# Altium schematic sheet DISPLAY&PINOUT.SchDoc(DISPLAY&PINOUT)
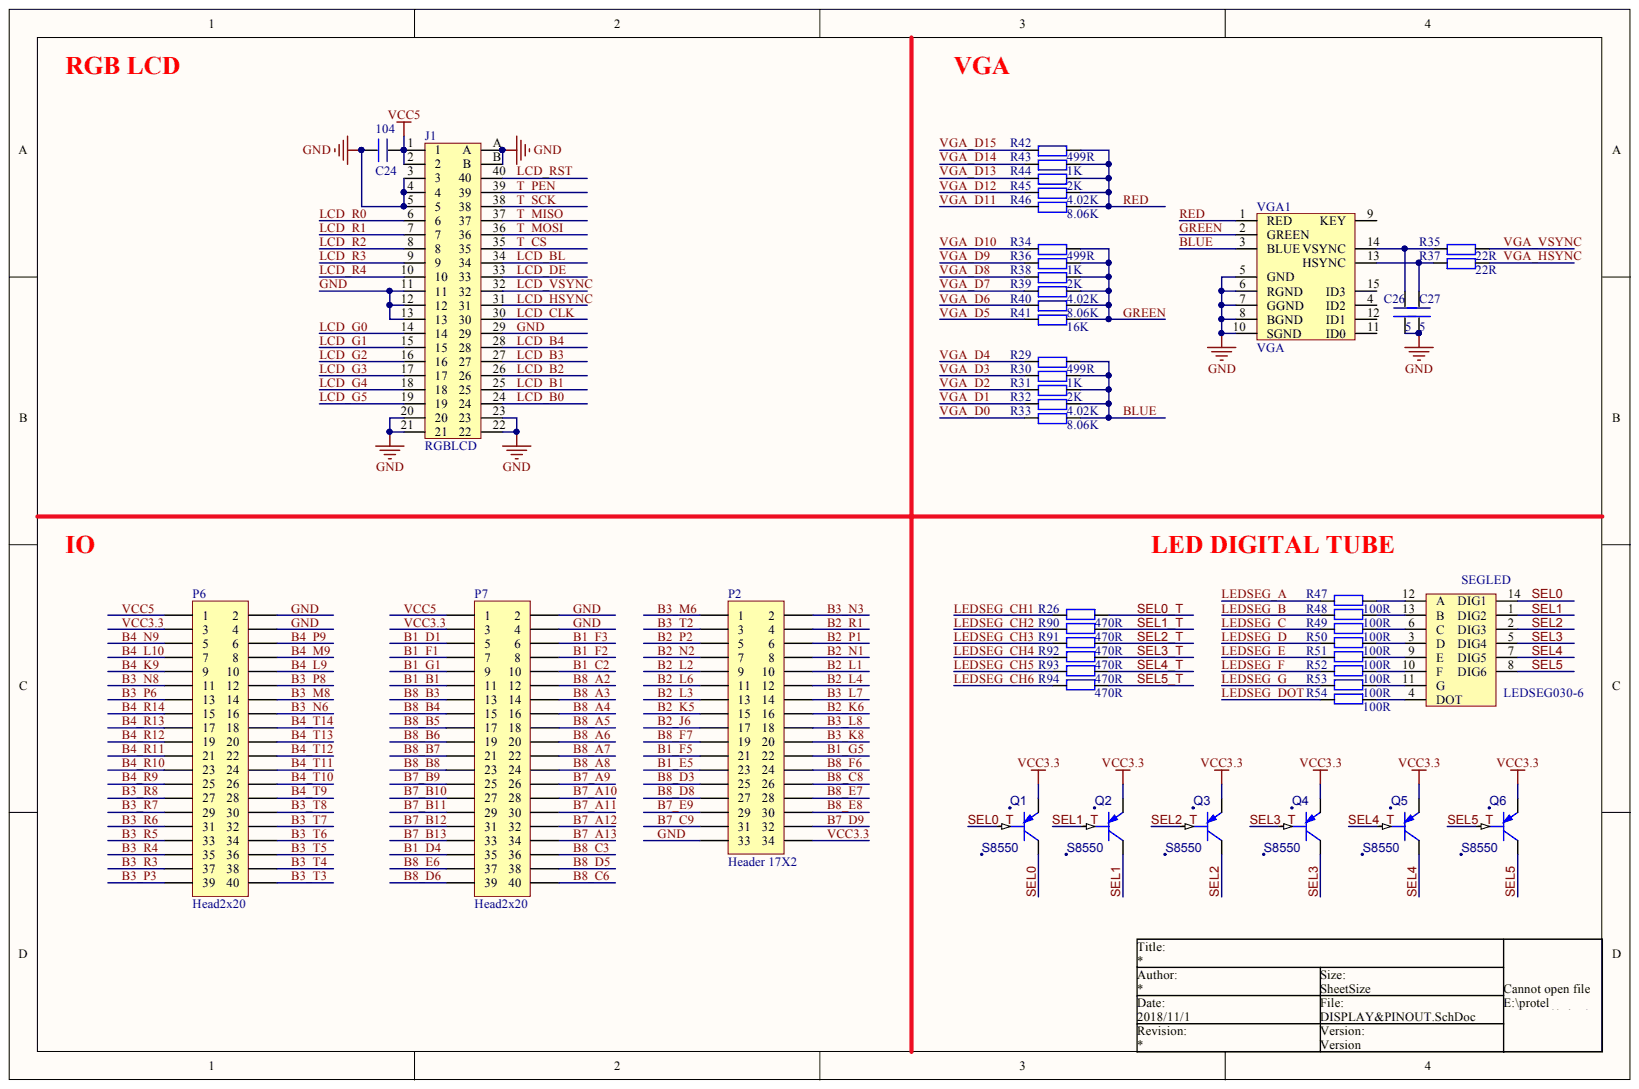
[diagram p0: DISPLAY&PINOUT.SchDoc]

## Parts
C24  104  [0603P]
C26  5  [0603P]
C27  5  [0603P]
J1  RGBLCD  [FPC-TOP40]
P2  Header 17X2  [HDR2X17]
P6  Head2x20  [IDC-40J]
P7  Head2x20  [IDC-40J]
Q1  S8550  [SOT23]
Q2  S8550  [SOT23]
Q3  S8550  [SOT23]
Q4  S8550  [SOT23]
Q5  S8550  [SOT23]
Q6  S8550  [SOT23]
R26  470R  [0603P]
R29  499R  [0603P]
R30  1K  [0603P]
R31  2K  [0603P]
R32  4.02K  [0603P]
R33  8.06K  [0603P]
R34  499R  [0603P]
R35  22R  [0603P]
R36  1K  [0603P]
R37  22R  [0603P]
R38  2K  [0603P]
R39  4.02K  [0603P]
R40  8.06K  [0603P]
R41  16K  [0603P]
R42  499R  [0603P]
R43  1K  [0603P]
R44  2K  [0603P]
R45  4.02K  [0603P]
R46  8.06K  [0603P]
R47  100R  [0603P]
R48  100R  [0603P]
R49  100R  [0603P]
R50  100R  [0603P]
R51  100R  [0603P]
R52  100R  [0603P]
R53  100R  [0603P]
R54  100R  [0603P]
R90  470R  [0603P]
R91  470R  [0603P]
R92  470R  [0603P]
R93  470R  [0603P]
R94  470R  [0603P]
SEGLED  LEDSEG030-6  [leddisplay-0.3]
VGA1  VGA  [DB15]

## Nets
B1_B1: P7.11
B1_C2: P7.10
B1_D1: P7.5
B1_D4: P7.35
B1_E5: P2.23
B1_F1: P7.7
B1_F2: P7.8
B1_F3: P7.6
B1_F5: P2.21
B1_G1: P7.9
B1_G5: P2.22
B2_J6: P2.17
B2_K5: P2.15
B2_K6: P2.16
B2_L1: P2.10
B2_L2: P2.9
B2_L3: P2.13
B2_L4: P2.12
B2_L6: P2.11
B2_N1: P2.8
B2_N2: P2.7
B2_P1: P2.6
B2_P2: P2.5
B2_R1: P2.4
B3_K8: P2.20
B3_L7: P2.14
B3_L8: P2.18
B3_M6: P2.1
B3_M8: P6.14
B3_N3: P2.2
B3_N6: P6.16
B3_N8: P6.11
B3_P3: P6.39
B3_P6: P6.13
B3_P8: P6.12
B3_R3: P6.37
B3_R4: P6.35
B3_R5: P6.33
B3_R6: P6.31
B3_R7: P6.29
B3_R8: P6.27
B3_T2: P2.3
B3_T3: P6.40
B3_T4: P6.38
B3_T5: P6.36
B3_T6: P6.34
B3_T7: P6.32
B3_T8: P6.30
B4_K9: P6.9
B4_L9: P6.10
B4_L10: P6.7
B4_M9: P6.8
B4_N9: P6.5
B4_P9: P6.6
B4_R9: P6.25
B4_R10: P6.23
B4_R11: P6.21
B4_R12: P6.19
B4_R13: P6.17
B4_R14: P6.15
B4_T9: P6.28
B4_T10: P6.26
B4_T11: P6.24
B4_T12: P6.22
B4_T13: P6.20
B4_T14: P6.18
B7_A9: P7.26
B7_A10: P7.28
B7_A11: P7.30
B7_A12: P7.32
B7_A13: P7.34
B7_B9: P7.25
B7_B10: P7.27
B7_B11: P7.29
B7_B12: P7.31
B7_B13: P7.33
B7_C9: P2.31
B7_D9: P2.32
B7_E9: P2.29
B8_A2: P7.12
B8_A3: P7.14
B8_A4: P7.16
B8_A5: P7.18
B8_A6: P7.20
B8_A7: P7.22
B8_A8: P7.24
B8_B3: P7.13
B8_B4: P7.15
B8_B5: P7.17
B8_B6: P7.19
B8_B7: P7.21
B8_B8: P7.23
B8_C3: P7.36
B8_C6: P7.40
B8_C8: P2.26
B8_D3: P2.25
B8_D5: P7.38
B8_D6: P7.39
B8_D8: P2.27
B8_E6: P7.37
B8_E7: P2.28
B8_E8: P2.30
B8_F6: P2.24
B8_F7: P2.19
BLUE: R29.2 R30.2 R31.2 R32.2 R33.2 VGA1.3(BLUE)
GND: C24.1 C26.2 C27.2 J1.3 J1.4 J1.5 J1.11 J1.12 J1.13 J1.20 J1.21 J1.22 J1.23 J1.29 J1.A J1.B P2.33 P6.2 P6.4 P7.2 P7.4 VGA1.5(GND) VGA1.6(RGND) VGA1.7(GGND) VGA1.8(BGND) VGA1.10(SGND)
GREEN: R34.2 R36.2 R38.2 R39.2 R40.2 R41.2 VGA1.2(GREEN)
LCD_B0: J1.24
LCD_B1: J1.25
LCD_B2: J1.26
LCD_B3: J1.27
LCD_B4: J1.28
LCD_BL: J1.34
LCD_CLK: J1.30
LCD_DE: J1.33
LCD_G0: J1.14
LCD_G1: J1.15
LCD_G2: J1.16
LCD_G3: J1.17
LCD_G4: J1.18
LCD_G5: J1.19
LCD_HSYNC: J1.31
LCD_R0: J1.6
LCD_R1: J1.7
LCD_R2: J1.8
LCD_R3: J1.9
LCD_R4: J1.10
LCD_RST: J1.40
LCD_VSYNC: J1.32
LEDSEG_A: R47.2
LEDSEG_B: R48.2
LEDSEG_C: R49.2
LEDSEG_CH1: R26.2
LEDSEG_CH2: R90.2
LEDSEG_CH3: R91.2
LEDSEG_CH4: R92.2
LEDSEG_CH5: R93.2
LEDSEG_CH6: R94.2
LEDSEG_D: R50.2
LEDSEG_DOT: R54.2
LEDSEG_E: R51.2
LEDSEG_F: R52.2
LEDSEG_G: R53.2
RED: R42.2 R43.2 R44.2 R45.2 R46.2 VGA1.1(RED)
SEL0: Q1.3 SEGLED.14(DIG1)
SEL0_T: Q1.1 R26.1
SEL1: Q2.3 SEGLED.1(DIG2)
SEL1_T: Q2.1 R90.1
SEL2: Q3.3 SEGLED.2(DIG3)
SEL2_T: Q3.1 R91.1
SEL3: Q4.3 SEGLED.5(DIG4)
SEL3_T: Q4.1 R92.1
SEL4: Q5.3 SEGLED.7(DIG5)
SEL4_T: Q5.1 R93.1
SEL5: Q6.3 SEGLED.8(DIG6)
SEL5_T: Q6.1 R94.1
T_CS: J1.35
T_MISO: J1.37
T_MOSI: J1.36
T_PEN: J1.39
T_SCK: J1.38
VCC3.3: P2.34 P6.3 P7.3 Q1.2 Q2.2 Q3.2 Q4.2 Q5.2 Q6.2
VCC5: C24.2 J1.1 J1.2 P6.1 P7.1
VGA_D0: R33.1
VGA_D1: R32.1
VGA_D2: R31.1
VGA_D3: R30.1
VGA_D4: R29.1
VGA_D5: R41.1
VGA_D6: R40.1
VGA_D7: R39.1
VGA_D8: R38.1
VGA_D9: R36.1
VGA_D10: R34.1
VGA_D11: R46.1
VGA_D12: R45.1
VGA_D13: R44.1
VGA_D14: R43.1
VGA_D15: R42.1
VGA_HSYNC: R37.2
VGA_VSYNC: R35.2
NetC26_1: C26.1 R35.1 VGA1.14(VSYNC)
NetC27_1: C27.1 R37.1 VGA1.13(HSYNC)
NetR47_1: R47.1 SEGLED.12(A)
NetR48_1: R48.1 SEGLED.13(B)
NetR49_1: R49.1 SEGLED.6(C)
NetR50_1: R50.1 SEGLED.3(D)
NetR51_1: R51.1 SEGLED.9(E)
NetR52_1: R52.1 SEGLED.10(F)
NetR53_1: R53.1 SEGLED.11(G)
NetR54_1: R54.1 SEGLED.4(DOT)

Not connected: VGA1 4(ID2) 9(KEY) 11(ID0) 12(ID1) 15(ID3)

## Library data of the parts
C24 C26 C27 = C: Component Kind: Standard
  C24: Library Name: ALIENTEK_COMPONENT.IntLib
  C26 C27: Library Name: MYCOMLIB.IntLib
J1 = FPC-40: FPC-40P-0.5mm; Component Kind: Standard; Library Name: ALIENTEK_COMPONENT.IntLib
P2 = Header 17X2: Header, 17-Pin, Dual row; Component Kind: Standard; LatestRevisionDate: 17-Jul-2002; LatestRevisionNote: Re-released for DXP Platform.; Library Name: Miscellaneous Connectors.IntLib; Signal Integrity: Connector; Publisher: Altium Limited
P6 P7 = MHDR2X20: Header, 20-Pin, Dual row; Component Kind: Standard; LatestRevisionDate: 17-Jul-2002; LatestRevisionNote: Re-released for DXP Platform.; Library Name: Warship V1.00.SCHLIB; Publisher: Altium Limited
  P7: Signal Integrity: Connector
Q1-Q6 = PNP: Component Kind: Standard
R26 R29-R54 R90-R94 = R: Component Kind: Standard; Library Name: ALIENTEK_COMPONENT.IntLib
SEGLED = LEDSEG030-6: 6位数码管,0.3寸,共阴,41*11*5.8mm; Component Kind: Standard; Library Name: ALIENTEK_COMPONENT.IntLib
VGA1 = VGA: Component Kind: Standard; Library Name: ALIENTEK_COMPONENT.IntLib
